# Altium schematic sheet IncubatorSheet.SchDoc(IncubatorSheet)
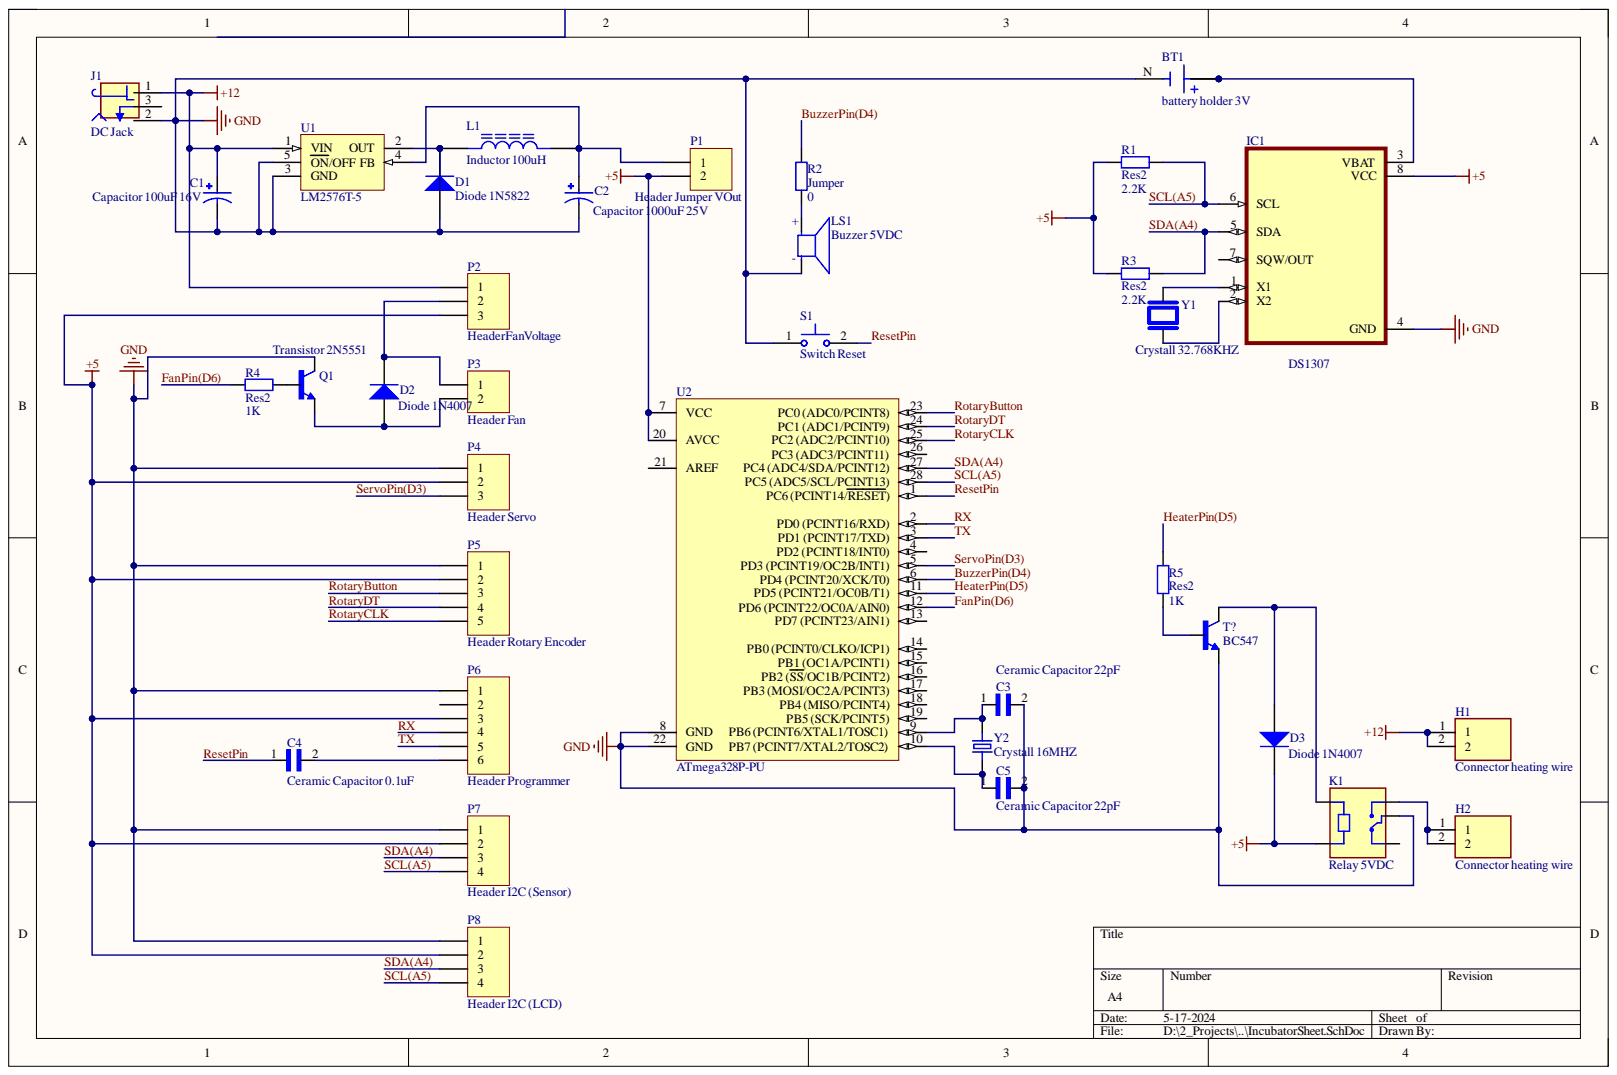
[diagram p0: IncubatorSheet.SchDoc]

## Parts
BT1  battery holder 3V  [BAT_1074]
C1  Capacitor 100uF 16V  [CAPPRD250W50D500H1100]
C2  Capacitor 1000uF 25V  [CAPPRD500W60D1250H2500]
C3  Ceramic Capacitor 22pF  [CAP_RDE5C2A220J0M1H03A]
C4  Ceramic Capacitor 0.1uF  [CAP_RDE5C2A220J0M1H03A]
C5  Ceramic Capacitor 22pF  [CAP_RDE5C2A220J0M1H03A]
D1  Diode 1N5822  [DO-201AD]
D2  Diode 1N4007  [DO-41]
D3  Diode 1N4007  [DO-41]
H1  Connector heating wire  [TERMINAL_PCRS-250]
H2  Connector heating wire  [TERMINAL_PCRS-250]
IC1  DS1307  [DIP794W47P254L991H457Q8]
J1  DC Jack  [XKB_DC-005-5A-2.0]
K1  Relay 5VDC  [RELAY_SRD-05VDC-SL-C]
L1  Inductor 100uH  [IND_RLB9012-101KL]
LS1  Buzzer 5VDC  [CUI_CMI-1295IC-0585T]
P1  Header Jumper VOut  [HDR1X2]
P2  HeaderFanVoltage  [HDR1X3]
P3  Header Fan  [HDR1X2]
P4  Header Servo  [HDR1X3]
P5  Header Rotary Encoder  [HDR1X5]
P6  Header Programmer  [HDR1X6]
P7  Header I2C (Sensor)  [HDR1X4]
P8  Header I2C (LCD)  [HDR1X4]
Q1  Transistor 2N5551  [TO92127P520H787-3]
R1  Res2  value 2.2K  [AXIAL-0.4]
R2  Jumper  value 0  [AXIAL-0.4]
R3  Res2  value 2.2K  [AXIAL-0.4]
R4  Res2  value 1K  [AXIAL-0.4]
R5  Res2  value 1K  [AXIAL-0.4]
S1  Switch Reset  [SW_BUTT-2]
T?  BC547  [TO92]
U1  LM2576T-5  [314D-03]
U2  ATmega328P-PU  [28P3]
Y1  Crystall 32.768KHZ  [XTAL_AB15T-32.768KHZ]
Y2  Crystall 16MHZ  [XTAL_ECS-160-20-4X]

## Nets
+5: D3.2 IC1.8(VCC) K1.A2 P1.2 P2.3 P4.2 P5.2 P6.3 P7.2 P8.2 R1.1 R3.1 U2.7(VCC) U2.20(AVCC)
+12: C1.P H1.1 H1.2 J1.1 P2.1 U1.1(VIN)
GND: BT1.N C1.N C2.N C3.2 C5.2 D1.1 IC1.4(GND) J1.2 K1.COM LS1.N P4.1 P5.1 P6.1 P7.1 P8.1 Q1.3 S1.1 T?.1 U1.3(GND) U1.5(ON/OFF) U2.8(GND) U2.22(GND)
BuzzerPin(D4): R2.1 U2.6(PD4_(PCINT20/XCK/T0))
FanPin(D6): R4.1 U2.12(PD6_(PCINT22/OC0A/AIN0))
HeaterPin(D5): R5.2 U2.11(PD5_(PCINT21/OC0B/T1))
ResetPin: C4.1 S1.2 U2.1(PC6_(PCINT14/RESET))
RotaryButton: P5.3 U2.23(PC0_(ADC0/PCINT8))
RotaryCLK: P5.5 U2.25
RotaryDT: P5.4 U2.24(PC1_(ADC1/PCINT9))
RX: P6.4 U2.2(PD0_(PCINT16/RXD))
SCL(A5): IC1.6(SCL) P7.4 P8.4 R1.2 U2.28(PC5_(ADC5/SCL/PCINT13))
SDA(A4): IC1.5(SDA) P7.3 P8.3 R3.2 U2.27
ServoPin(D3): P4.3 U2.5(PD3_(PCINT19/OC2B/INT1))
TX: P6.5 U2.3(PD1_(PCINT17/TXD))
NetBT1_P_1: BT1.P_1 BT1.P_2 IC1.3(VBAT)
NetC2_P: C2.P L1.2 P1.1 U1.4(FB)
NetC3_1: C3.1 U2.9 Y2.1
NetC4_2: C4.2 P6.6
NetC5_1: C5.1 U2.10 Y2.2
NetD1_2: D1.2 L1.1 U1.2(OUT)
NetD2_1: D2.1 P3.2 Q1.1
NetD2_2: D2.2 P2.2 P3.1
NetD3_1: D3.1 K1.A1 T?.3
NetH2_1: H2.1 H2.2 K1.NO
NetIC1_1: IC1.1(X1) Y1.1
NetIC1_2: IC1.2(X2) Y1.2
NetLS1_P: LS1.P R2.2
NetQ1_2: Q1.2 R4.2
NetR5_1: R5.1 T?.2

Not connected: IC1 7(SQW/OUT); J1 3; K1 NC; P6 2; U2 4(PD2_(PCINT18/INT0)) 13(PD7_(PCINT23/AIN1)) 14(PB0_(PCINT0/CLKO/ICP1)) 15(PB1_(OC1A/PCINT1)) 16(PB2_(SS/OC1B/PCINT2)) 17(PB3_(MOSI/OC2A/PCINT3)) 18(PB4_(MISO/PCINT4)) 19(PB5_(SCK/PCINT5)) 21(AREF) 26(PC3_(ADC3/PCINT11))

## Library data of the parts
BT1 = 1074: Availability: Unavailable; Component Kind: Standard; MP: 1074; Package: None; Price: None; Library Name: CoinCellBattery holder_1074.IntLib
C1 = EEUHD1C101: CAP ALUM 100UF 20% 16V RADIAL; MF: Panasonic; Availability: In Stock; Check_prices: https://www.snapeda.com/parts/EEUHD1C101/Panasonic/view-part/5791153/?ref=eda; Component Kind: Standard; Library Name: Capacitor100uF16V_EEUHD1C101.IntLib; MP: EEUHD1C101; Package: RADIAL-2 Panasonic; Price: None; SnapEDA_Link: https://www.snapeda.com/parts/EEUHD1C101/Panasonic/view-part/5791153/?ref=snap
C2 = EEUTA1E102LB: CAP ALUM 1000UF 20% 25V RADIAL; MF: Panasonic; Availability: No availability data; Check_prices: https://www.snapeda.com/parts/EEUTA1E102LB/Panasonic/view-part/5789306/?ref=eda; Component Kind: Standard; Library Name: Capacitor1000uF25V_EEUTA1E102LB.IntLib; MP: EEUTA1E102LB; Package: RADIAL-2 Panasonic; Price: None; SnapEDA_Link: https://www.snapeda.com/parts/EEUTA1E102LB/Panasonic/view-part/5789306/?ref=snap
C3-C5 = RDE5C2A220J0M1H03A: Lead Capacitor C0G_EIA_ with Capacitance: 22pF and Rated Voltage: 100Vdc; MF: Murata; Availability: Unavailable; Component Kind: Standard; Library Name: CeramicCapacitor22pf_RDE5C2A220J0M1H03A.IntLib; MP: RDE5C2A220J0M1H03A; Package: Radial Murata; Price: None; SnapEDA_Link: https://www.snapeda.com/parts/RDE5C2A220J0M1H03A/Murata/view-part/4545539/?ref=snap
D1 = Diode 1N5408: 3 Amp Medium Power Silicon Rectifier Diode; Component Kind: Standard; ComponentLink1Description: Manufacturer Link; ComponentLink1URL: http://www.irf.com/; DatasheetVersion: Aug-1984; LatestRevisionDate: 17-Jul-2002; LatestRevisionNote: Re-released for DXP Platform.; Library Name: Miscellaneous Devices.IntLib; PackageDescription: Diode, Axial; Body 9.5 x 5.3 mm (LxDia. max), Lead Dia. 1.32 mm (max); PackageReference: DO-201AD; Published: 8-Jun-2000; Signal Integrity: 1N5408; Simulation: 1N5408; Publisher: Altium Limited
D2 D3 = Diode 1N4007: 1 Amp General Purpose Rectifier; Code_JEDEC: DO-204-AL; Component Kind: Standard; ComponentLink1Description: Manufacturer Link; ComponentLink1URL: http://www.fairchildsemi.com/; ComponentLink2Description: Datasheet; ComponentLink2URL: http://www.fairchildsemi.com/ds/1N%2F1N4001.pdf; DatasheetVersion: 1998; LatestRevisionDate: 17-Jul-2002; LatestRevisionNote: Re-released for DXP Platform.; Library Name: Miscellaneous Devices.IntLib; PackageDescription: Diode, Axial; Body 5.2 x 2.7 mm (LxDia. max), Lead Dia. 0.86 mm (max); PackageReference: DO-41; PackageVersion: Aug-1999; Published: 8-Jun-2000; Signal Integrity: 1N4007; Simulation: 1N4007; Publisher: Altium Limited
H1 H2 = PCRS-250: PCRS250- Valcon PCB Mount Spade Terminal, 10.5mm PCB Profile; Availability: Unavailable; Component Kind: Standard; MP: PCRS-250; Package: None; Price: None; Library Name: CrimpTerminalBrassMale_PCRS-250.IntLib
IC1 = DS1307: Real Time Clock (RTC) IC Clock/Calendar 56B IÂ²C, 2-Wire Serial 8-SOIC (0.154, 3.90mm Width); MF: Maxim Integrated; Availability: In Stock; Check_prices: https://www.snapeda.com/parts/DS1307/Maxim+Integrated/view-part/?ref=eda; Component Kind: Standard; Library Name: DS1307.IntLib; MP: DS1307; Package: DIP-8 Maxim Integrated; Price: None; Purchase-URL: https://pricing.snapeda.com/search/part/DS1307/?ref=eda; SnapEDA_Link: https://www.snapeda.com/parts/DS1307/Maxim+Integrated/view-part/?ref=snap
J1 = DC-005-5A-2.0: DC Power Receptacle Jack, Through Holeï¼ŒRight Angle; MF: XKB Industrial Precision; Check_prices: https://www.snapeda.com/parts/DC-005-5A-2.0/XKB+Industrial+Precision/view-part/?ref=eda; Component Kind: Standard; Library Name: DC-005-5A-2.0.IntLib; MP: DC-005-5A-2.0; Package: Package; SnapEDA_Link: https://www.snapeda.com/parts/DC-005-5A-2.0/XKB+Industrial+Precision/view-part/?ref=snap
K1 = SRD-05VDC-SL-C: 5V Trigger Relay Module For Arduino And Raspberry Pi 5V Trigger Relay Module For Arduino And Raspberry Pi; MF: Songle Relay; Availability: Not in stock; Check_prices: https://www.snapeda.com/parts/SRD-05VDC-SL-C/Songle+Relay/view-part/?ref=eda; Component Kind: Standard; Library Name: Relay-SRD-05VDC-SL-C.IntLib; MP: SRD-05VDC-SL-C; Package: NON STANDARD-5 Songle Relay; Price: None; SnapEDA_Link: https://www.snapeda.com/parts/SRD-05VDC-SL-C/Songle+Relay/view-part/?ref=snap
L1 = RLB9012-101KL: Availability: Unavailable; Component Kind: Standard; MP: RLB9012-101KL; Package: None; Price: None; Library Name: Incudtor100uH_RLB9012-101KL.IntLib
LS1 = CMI-1295IC-0585T: 12 mm, 5 Vdc, 85 dB, Through Hole, Driving Circuit, Magnetic Audio Indicator Buzzer; MF: CUI Devices; Availability: In Stock; Check_prices: https://www.snapeda.com/parts/CMI-1295IC-0585T/CUI+Devices/view-part/?ref=eda; Component Kind: Standard; CUI_purchase_URL: https://www.cuidevices.com/product/audio/buzzers/audio-indicators/cmi-1295ic-0585t?utm_source=snapeda.com&utm_medium=referral&utm_campaign=snapedaBOM; Library Name: Buzzer-CMI-1295IC-0585T.IntLib; MP: CMI-1295IC-0585T; Package: Package; Price: None; Purchase-URL: https://pricing.snapeda.com/search/part/CMI-1295IC-0585T/?ref=eda; SnapEDA_Link: https://www.snapeda.com/parts/CMI-1295IC-0585T/CUI+Devices/view-part/?ref=snap
P1 P3 = Header 2: Header, 2-Pin; Component Kind: Standard; LatestRevisionDate: 17-Jul-2002; LatestRevisionNote: Re-released for DXP Platform.; Library Name: Miscellaneous Connectors.IntLib; Signal Integrity: Connector; Publisher: Altium Limited
P2 P4 = Header 3: Header, 3-Pin; Component Kind: Standard; LatestRevisionDate: 17-Jul-2002; LatestRevisionNote: Re-released for DXP Platform.; Library Name: Miscellaneous Connectors.IntLib; Signal Integrity: Connector; Publisher: Altium Limited
P5 = Header 5: Header, 5-Pin; Component Kind: Standard; LatestRevisionDate: 17-Jul-2002; LatestRevisionNote: Re-released for DXP Platform.; Library Name: Miscellaneous Connectors.IntLib; Signal Integrity: Connector; Publisher: Altium Limited
P6 = Header 6: Header, 6-Pin; Component Kind: Standard; LatestRevisionDate: 17-Jul-2002; LatestRevisionNote: Re-released for DXP Platform.; Library Name: Miscellaneous Connectors.IntLib; Signal Integrity: Connector; Publisher: Altium Limited
P7 P8 = Header 4: Header, 4-Pin; Component Kind: Standard; LatestRevisionDate: 17-Jul-2002; LatestRevisionNote: Re-released for DXP Platform.; Library Name: Miscellaneous Connectors.IntLib; Signal Integrity: Connector; Publisher: Altium Limited
Q1 = 2N5551: Bipolar (BJT) Transistor NPN 160 V 600 mA 300MHz 625 mW Through Hole TO-92 (TO-226); MF: ON Semiconductor; Availability: Not in stock; Check_prices: https://www.snapeda.com/parts/2N5551/Onsemi/view-part/?ref=eda; Component Kind: Standard; Library Name: 2N5551.IntLib; MP: 2N5551; Package: E-PKG AXIAL-LEADED-2 ON Semiconductor; Price: None; SnapEDA_Link: https://www.snapeda.com/parts/2N5551/Onsemi/view-part/?ref=snap
R1 R2 R4 R5 = Res2: Resistor; Component Kind: Standard; LatestRevisionDate: 17-Jul-2002; LatestRevisionNote: Re-released for DXP Platform.; Library Name: Miscellaneous Devices.IntLib; PackageDescription: Axial Device, Thru-Hole; 2 Leads; 0.4 in Pin Spacing; PackageReference: AXIAL-0.4; Published: 8-Jun-2000; Signal Integrity: Res; Simulation: RESISTOR; Publisher: Altium Limited
R3 = Res2: Resistor; Value: 2.2K; Component Kind: Standard; LatestRevisionDate: 17-Jul-2002; LatestRevisionNote: Re-released for DXP Platform.; Library Name: Miscellaneous Devices.IntLib; PackageDescription: Axial Device, Thru-Hole; 2 Leads; 0.4 in Pin Spacing; PackageReference: AXIAL-0.4; Published: 8-Jun-2000; Signal Integrity: Res; Simulation: RESISTOR; Publisher: Altium Limited
S1 = BUTT-2: Tactile Switches MINI PUSH BUTTON SWITCH 2-PIN QTY. 4; MF: Gravitech; Availability: In Stock; Check_prices: https://www.snapeda.com/parts/BUTT-2/Gravitech/view-part/?ref=eda; Component Kind: Standard; Library Name: Button_BUTT-2.IntLib; MP: BUTT-2; Package: None; Price: None; Purchase-URL: https://pricing.snapeda.com/search/part/BUTT-2/?ref=eda; SnapEDA_Link: https://www.snapeda.com/parts/BUTT-2/Gravitech/view-part/?ref=snap
T? = BC547: Bipolar (BJT) Transistor NPN 45V 100mA 300MHz 625mW Through Hole TO-92 (TO-226); MF: ON; Availability: In Stock; Check_prices: https://www.snapeda.com/parts/BC547/Onsemi/view-part/?ref=eda; Component Kind: Standard; Library Name: BC547.IntLib; MP: BC547; Package: E-PKG AXIAL-LEADED-2 ON Semiconductor; Price: None; Purchase-URL: https://pricing.snapeda.com/search/part/BC547/?ref=eda; SnapEDA_Link: https://www.snapeda.com/parts/BC547/Onsemi/view-part/?ref=snap
U1 = LM2576T-5: Easy Switcher 3.0A Step-Down Voltage Regulator; Code_JEDEC: TS-001-AA; Component Kind: Standard; ComponentLink1Description: Manufacturer Link; ComponentLink1URL: http://www.onsemi.com/site/products/taxonomy/0,4438,503,00.html; ComponentLink2Description: Datasheet; ComponentLink2URL: http://www.onsemi.com/pub/Collateral/LM2576-D.PDF; DatasheetVersion: Jul-1999; Library Name: ON Semi Power Mgt Voltage Regulator.IntLib; PackageDescription: TO, Thru-Hole, Vertical, Heatsink Mounted; 5 In-Line Leads; Pitch 1.70 mm; PackageReference: 314D-03; PackageVersion: Jul-1999; Published: 15-Apr-2002; Publisher: Altium Limited
U2 = ATmega328P-PU: 8-bit AVR Microcontroller, 32KB Flash, 1KB EEPROM, 2KB SRAM, 28-pin PDIP, Industrial Grade (-40°C to 85°C); Component Kind: Standard; ComponentLink1Description: Manufacturer URL; ComponentLink1URL: http://www.atmel.com/; ComponentLink2Description: ATmega48A/48PA/88A/88PA/168A/168PA/328/328P Datasheet; ComponentLink2URL: http://www.atmel.com/dyn/resources/prod_documents/8271.pdf; ComponentLink3Description: ATmega48A/48PA/88A/88PA/168A/168PA/328/328P Summary; ComponentLink3URL: http://www.atmel.com/dyn/resources/prod_documents/8271S.pdf; DatasheetVersion: revD, May-2011; Library Name: Atmel Microcontroller megaAVR.IntLib; PackageDescription: 28-lead (0.300"/7.62mm Wide) Plastic Dual Inline Package (PDIP); PackageReference: 28P3; PackageVersion: revB, Sep-2001
Y1 = AB15T-32.768KHZ: Availability: Unavailable; Component Kind: Standard; Library Name: Crystall_AB15T-32.768KHZ.IntLib; MP: AB15T-32.768KHZ; Package: None; Price: None
Y2 = ECS-160-S-4: 16MHz Â±30ppm Crystal Series 40 Ohms HC-49/US; MF: ECS Inc.; Availability: Unavailable; Component Kind: Standard; Library Name: Crystall-ECS-160-S-4.IntLib; MP: ECS-160-S-4; Package: HC49/US ECS International; Price: None; SnapEDA_Link: https://www.snapeda.com/parts/ECS-160-S-4/ECS%20Inc./view-part/3657575/?ref=snap
